# Altium schematic sheet Z Driver.SchDoc
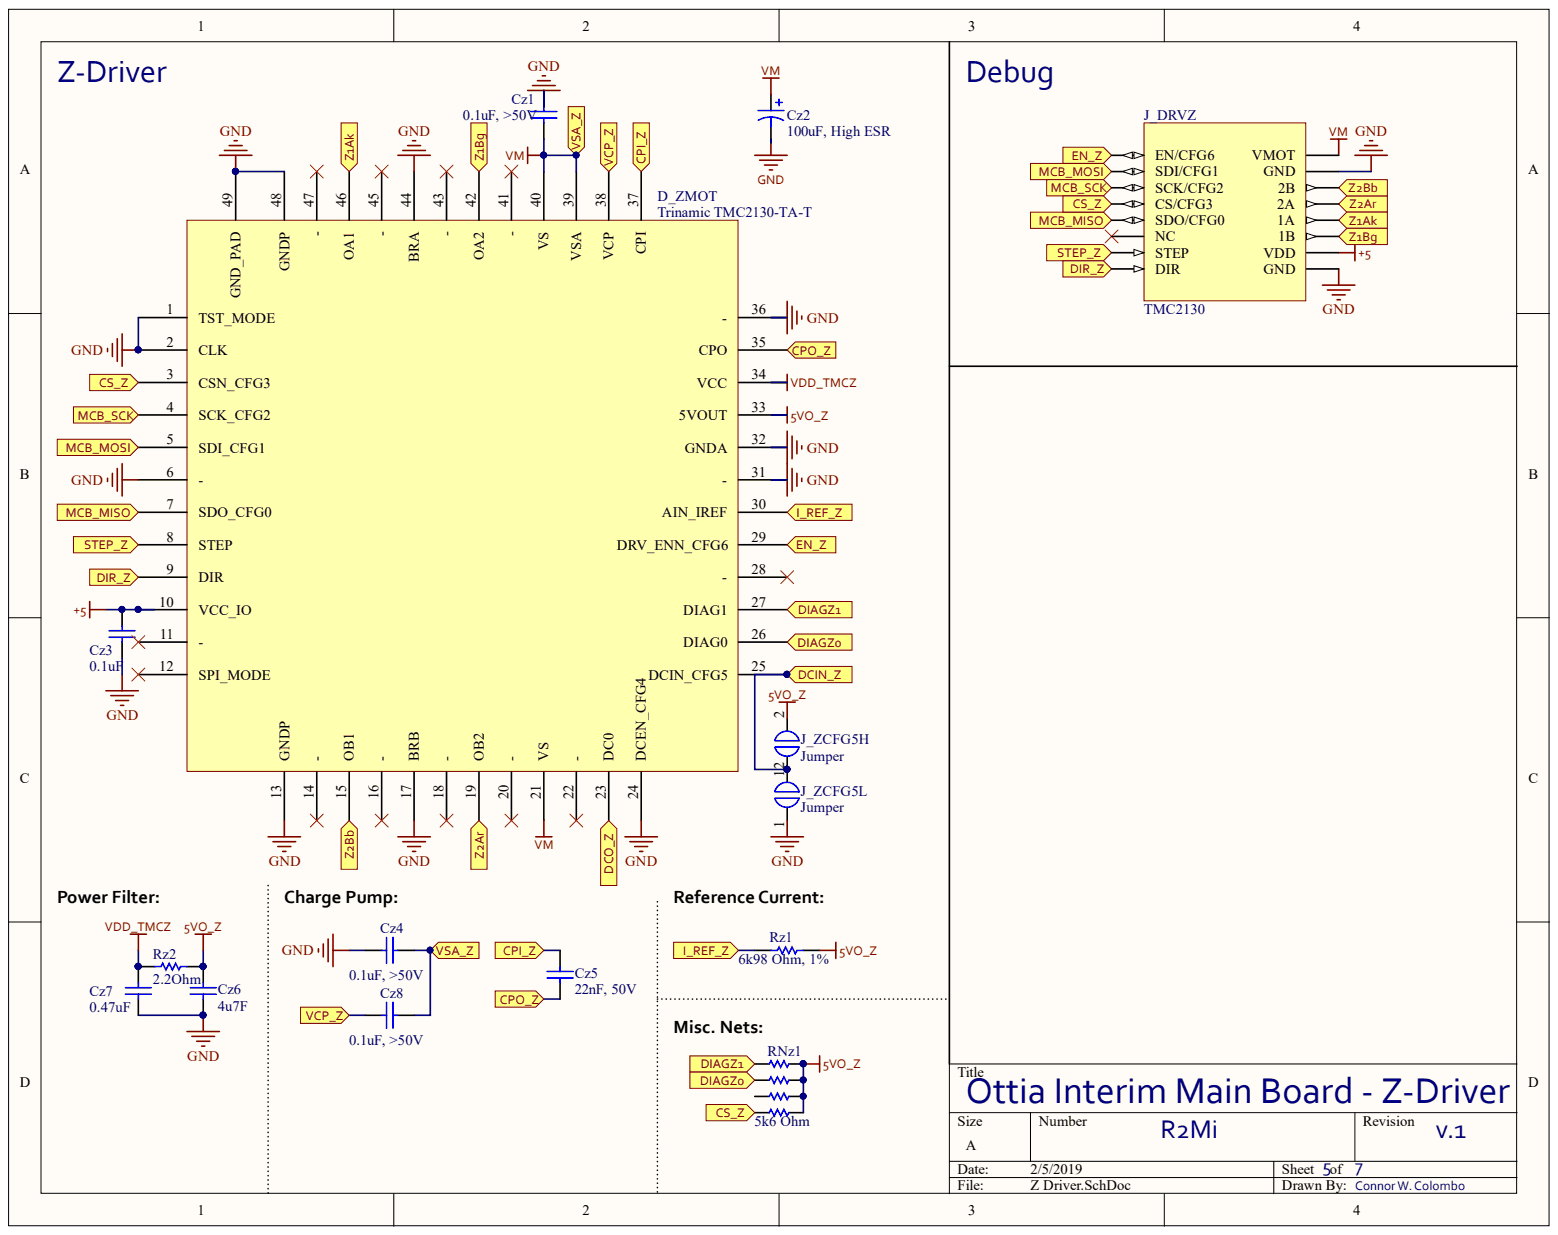
[diagram p0: Z Driver.SchDoc]

## Parts
Cz1  0.1uF, >50V  [CAPC3225X230X50LL20T25]
Cz2  100uF, High ESR  [PCB-jdn2nc4rwmt5jba04pvn-1]
Cz3  0.1uF  [PCB-eedgklwdvp799dppbzpc-1]
Cz4  0.1uF, >50V  [CAPC3225X230X50LL20T25]
Cz5  22nF, 50V  [CAPC2013X88X50LL20T25]
Cz6  4u7F  [PCB-nhmcdtn4fi4bskelbskt-1]
Cz7  0.47uF  [CAPC1608X90X35LL15T15]
Cz8  0.1uF, >50V  [CAPC3225X230X50LL20T25]
D_ZMOT  Trinamic TMC2130-TA-T  Manufacturer Part Number: TMC2130-TA-T  [PCB-4ozj7ixy6tn0k538l3w0-1]
J_DRVZ  TMC2130  [PCB-9s71h3a9oy4xnjzl8nlp-1]
J_ZCFG5H  Jumper  [PCB-6gvn9cty3r54iuqtdtvh-1]
J_ZCFG5L  Jumper  [PCB-6gvn9cty3r54iuqtdtvh-1]
RNz1  5k6 Ohm  [PCB-1201p24ncc8e2pnsi1d0-1]
Rz1  6k98 Ohm, 1%  [RESC1005X40X25NL05T05]
Rz2  2.2Ohm  [PCB-6i880wu5cbi7awo9xd9u-1]

## Ports
CPI_Z, CPO_Z, CS_Z, DCIN_Z, DCO_Z, DIAGZ0, DIAGZ1, DIR_Z, EN_Z, I_REF_Z, MCB_MISO, MCB_MOSI, MCB_SCK, STEP_Z, VCP_Z, VSA_Z, Z1AK, Z1BG, Z2AR, Z2BB

## Nets
5VO_Z: Cz6.1 D_ZMOT.33(5VOUT) J_ZCFG5H.2 RNz1.5 RNz1.6 RNz1.7 RNz1.8 Rz1.2 Rz2.1
+5: Cz3.2 D_ZMOT.10(VCC_IO) J_DRVZ.VDD
GND: Cz1.2 Cz2.2 Cz3.1 Cz4.1 Cz6.2 Cz7.1 D_ZMOT.1(TST_MODE) D_ZMOT.2(CLK) D_ZMOT.6(-) D_ZMOT.13(GNDP) D_ZMOT.17(BRB) D_ZMOT.24(DCEN_CFG4) D_ZMOT.31(-) D_ZMOT.32(GNDA) D_ZMOT.36(-) D_ZMOT.44(BRA) D_ZMOT.48(GNDP) D_ZMOT.49(GND_PAD) J_DRVZ.GND J_ZCFG5L.1
VDD_TMCZ: Cz7.2 D_ZMOT.34(VCC) Rz2.2
CPI_Z: Cz5.2 D_ZMOT.37(CPI) ; port CPI_Z
CPO_Z: Cz5.1 D_ZMOT.35(CPO) ; port CPO_Z
CS_Z: D_ZMOT.3(CSN_CFG3) J_DRVZ.CS/CFG3 RNz1.4 ; port CS_Z
DCIN_Z: D_ZMOT.25(DCIN_CFG5) J_ZCFG5H.1 J_ZCFG5L.2 ; port DCIN_Z
DCO_Z: D_ZMOT.23(DC0) ; port DCO_Z
DIAGZ0: D_ZMOT.26(DIAG0) RNz1.2 ; port DIAGZ0
DIAGZ1: D_ZMOT.27(DIAG1) RNz1.1 ; port DIAGZ1
DIR_Z: D_ZMOT.9(DIR) J_DRVZ.DIR ; port DIR_Z
EN_Z: D_ZMOT.29(DRV_ENN_CFG6) J_DRVZ.EN/CFG6 ; port EN_Z
I_REF_Z: D_ZMOT.30(AIN_IREF) Rz1.1 ; port I_REF_Z
MCB_MISO: D_ZMOT.7(SDO_CFG0) J_DRVZ.SDO/CFG0 ; port MCB_MISO
MCB_MOSI: D_ZMOT.5(SDI_CFG1) J_DRVZ.SDI/CFG1 ; port MCB_MOSI
MCB_SCK: D_ZMOT.4(SCK_CFG2) J_DRVZ.SCK/CFG2 ; port MCB_SCK
STEP_Z: D_ZMOT.8(STEP) J_DRVZ.STEP ; port STEP_Z
VCP_Z: Cz8.1 D_ZMOT.38(VCP) ; port VCP_Z
VM: Cz1.1 Cz2.1 Cz4.2 Cz8.2 D_ZMOT.21(VS) D_ZMOT.39(VSA) D_ZMOT.40(VS) J_DRVZ.VMOT ; port VSA_Z
NetD_ZMOT_15: D_ZMOT.15(OB1) J_DRVZ.2B ; port Z2BB
NetD_ZMOT_19: D_ZMOT.19(OB2) J_DRVZ.2A ; port Z2AR
NetD_ZMOT_42: D_ZMOT.42(OA2) J_DRVZ.1B ; port Z1BG
NetD_ZMOT_46: D_ZMOT.46(OA1) J_DRVZ.1A ; port Z1AK

Not connected: D_ZMOT 11(-) 12(SPI_MODE) 14(-) 16(-) 18(-) 20(-) 22(-) 28(-) 41(-) 43(-) 45(-) 47(-); J_DRVZ NC; RNz1 3

## Library data of the parts
Cz1 Cz4 Cz8 = C1210V104KCRACTU: Multilayer Ceramic Capacitors MLCC - SMD/SMT 500volts 0.1uF 10% X7R; Capacitance: 1e-07 F; Lead-Free Status: R; Voltage Rating (DC): 500.0 V; Capacitance Tolerance: ±10%; Case/Package: 1210; Component Kind: Standard; Dielectric Characteristic: X7R; Dielectric Material: Ceramic; Library Name: Octopart library; Mounting Style: Surface Mount; OctopartID: 4e52860b66549195; Octopart Url: https://octopart.com/c1210v104kcractu-kemet-22220128; Packaging: Cut Tape (CT); REACH SVHC Compliance: No SVHC; RoHS: Compliant
Cz2 = CMP-fe0c362b36de21cb-2: Cap Aluminum Lytic 100uF 50V 20% (6.3 X 7.7mm) SMD 0.34 Ohm 350mA 2000h 105C Automotive T/R; Manufacturer Part Number: EEEFTH101XAP; Capacitance: 100 µF; Dielectric Material: Aluminum Electrolytic; Equivalent Series Resistance (ESR): 340 mΩ; Lead-Free Status: Lead Free; Voltage Rating (DC): 50.0 V; Capacitance Tolerance: ±20%; Component Kind: Standard; Library Name: CommunityVault; Lifecycle Status: Not Listed by Manufacturer; Number of Pins: 2; OctopartID: fe0c362b36de21cb; Octopart URL: http://octopart.com/eeefth101xap-panasonic-19787188; Packaging: Tape & Reel (TR), Cut Tape (CT); REACH SVHC Compliance: No SVHC; RoHS: Compliant; Size-Diameter: 6.30 mm; Size-Height: 7.70 mm
Cz3 = CMP-48bd81bce6dc977a-1: NFM Series 0603 0.1 uF 2 A 16 V SMT Chip Capacitor Type EMI Filter; Manufacturer Part Number: NFM18PC104R1C3D; Capacitance: 100 nF; Current Rating: 2.00 A; Lead-Free Status: Lead Free; Shielding: No; Voltage Rating (DC): 16.0 V; Case/Package: 0603; Component Kind: Standard; Library Name: FavoritesLibrary; Mounting Style: Surface Mount; OctopartID: 48bd81bce6dc977a; Octopart URL: https://octopart.com/nfm18pc104r1c3d-murata-756487; Packaging: Cut Tape (CT); REACH SVHC Compliance: No SVHC; RoHS: Compliant; Size-Length: 1.60 mm; Size-Width: 800 µm
Cz5 = C0805C223K5RACTU: Capacitance: 2.2e-08 F; Capacitance Tolerance: ±10%; Case/Package (SI): 2012; Dielectric Characteristic: X7R; Dielectric Material: Ceramic; Lead-Free Status: R; Voltage Rating (DC): 50.0 V; Case/Package: 0805; Component Kind: Standard; Library Name: Octopart library; Mounting Style: Surface Mount; Number of Pins: 2; OctopartID: 91b558bd8ee34e72; Octopart Url: https://octopart.com/c0805c223k5ractu-kemet-145968; REACH SVHC Compliance: No SVHC; RoHS: Compliant; Size-Height: 0.00078 m; Size-Length: 0.002 m; Size-Width: 0.00125 m
Cz6 = GRM155R60J475ME47D: Capacitor: ceramic; 4.7uF; 6.3V; X5R; ±20%; SMD; 0402; Series: GRM; Voltage Rating (DC): 6.30 V; Component Kind: Standard; Library Name: Octopart library; Mounting Style: Surface Mount; OctopartID: 87124f5e047c58d5; RoHS: Compliant; Size-Length: 1.00 mm; Size-Width: 500 µm
Cz7 = 0603YD474KAT2A: CAP CER 0.47UF 16V 10% X5R 0603; Capacitance: 4.7e-07 F; Dielectric Material: Ceramic Multilayer; Lead-Free Status: Lead Free; Voltage Rating (DC): 16.0 V; Capacitance Tolerance: ±10%; Case/Package: 0603; Component Kind: Standard; Dielectric Characteristic: X5R; Library Name: Octopart library; Mounting Style: Surface Mount; Number of Pins: 2; OctopartID: d0e019e80c9e0f27; Octopart Url: https://octopart.com/0603yd474kat2a-avx+interconnect+%2F+elco-194840; REACH SVHC Compliance: No SVHC; RoHS: Compliant; Size-Height: 0.00086 m; Size-Length: 0.0016 m; Size-Width: 0.00081 m
D_ZMOT = CMP-3e6bf3f7b7f5e1ac-1: IC Stepper Motor Driver eTQFP48; Component Kind: Standard; Library Name: CommunityVault; OctopartID: 3e6bf3f7b7f5e1ac; Octopart URL: https://octopart.com/tmc2130-ta-t-trinamic-76983544
J_DRVZ = CMP-1b6cd6f092fcc4ab-4: Trinamic TMC2130 - Power Management, Motor Control, Stepper Evaluation Board; Manufacturer Part Number: TMC SILENTSTEPSTICK SPI; Component Kind: Standard; Library Name: FavoritesLibrary; OctopartID: 1b6cd6f092fcc4ab; Octopart URL: https://octopart.com/tmc+silentstepstick+spi-trinamic-76983542
J_ZCFG5H J_ZCFG5L = Solder Jumper-3: PCB Solder Jumper; CustomComponentID: 6gvn9cty3r54iuqtdtvh; Component Kind: Standard; Library Name: FavoritesLibrary; tYPE: Jumper
RNz1 = CMP-0aeac3f5d3eed52d-1: Res Thick Film Array 5.6K Ohm 5% ±200ppm/°C ISOL Molded 8-Pin 1206(4 X 0603) Convex SMD Paper T/R; Manufacturer Part Number: YC164-JR-075K6L; Lead-Free Status: Lead Free; Number of Circuits: 4; Number of Contacts: 8; Resistance: 5.60 kΩ; Voltage Rating (DC): 50.0 V; Case/Package: 0612; Component Kind: Standard; Library Name: CommunityVault; Mounting Style: Surface Mount; OctopartID: 0aeac3f5d3eed52d; Octopart URL: http://octopart.com/yc164-jr-075k6l-yageo-1440866; Resistance Tolerance: ±5%; RoHS: Compliant; Size-Height: 600 µm; Size-Length: 3.20 mm; Size-Width: 1.60 mm
Rz1 = CMP-3653839-2: Thick Film Resistors - SMD 0402 6.98Kohms 1% Tol; Lead-Free Status: Lead Free; Power Rating: 0.1 W; Resistance: 6980.0 Ω; Voltage Rating (DC): 50.0 V; Case/Package: 0402; Component Kind: Standard; Library Name: CommunityVault; Lifecycle Status: Not Listed by Manufacturer; Mounting Style: Surface Mount; OctopartID: b02a7a6d3c146fed; Octopart Url: https://octopart.com/erj-2rkf6981x-panasonic-55421272; REACH SVHC Compliance: No SVHC; Resistance Tolerance: ±1%; RoHS: Compliant; Size-Height: 0.00035 m; Size-Length: 0.001 m; Size-Width: 0.0005 m
Rz2 = CMP-6263a9198eebbda4-1: Res Thick Film 1206 2.2 Ohm 1% 0.25W(1/4W) ?200ppm/?C Molded SMD Paper T/R; Manufacturer Part Number: RC1206FR-072R2L; Case/Package (SI): 3216; Lead-Free Status: Lead Free; Power Rating: 250 mW; Resistance: 2.20 ?; Temperature Coefficient: -100 ppm/°C to 100 ppm/°C; Voltage Rating (DC): 200 V; Case/Package: 1206; Component Kind: Standard; Library Name: FavoritesLibrary; Mounting Style: Surface Mount; Number of Pins: 2; OctopartID: 6263a9198eebbda4; Octopart URL: https://octopart.com/rc1206fr-072r2l-yageo-40946158; Operating Temperature: 155 °C (max); Packaging: Tape & Reel; REACH SVHC Compliance: No SVHC; Resistance Tolerance: ±1%; RoHS: Compliant; Size-Height: 550 µm; Size-Length: 3.20 mm; Size-Width: 1.60 mm
